# Altium schematic sheet MainBoard_ETH_RASP.SchDoc
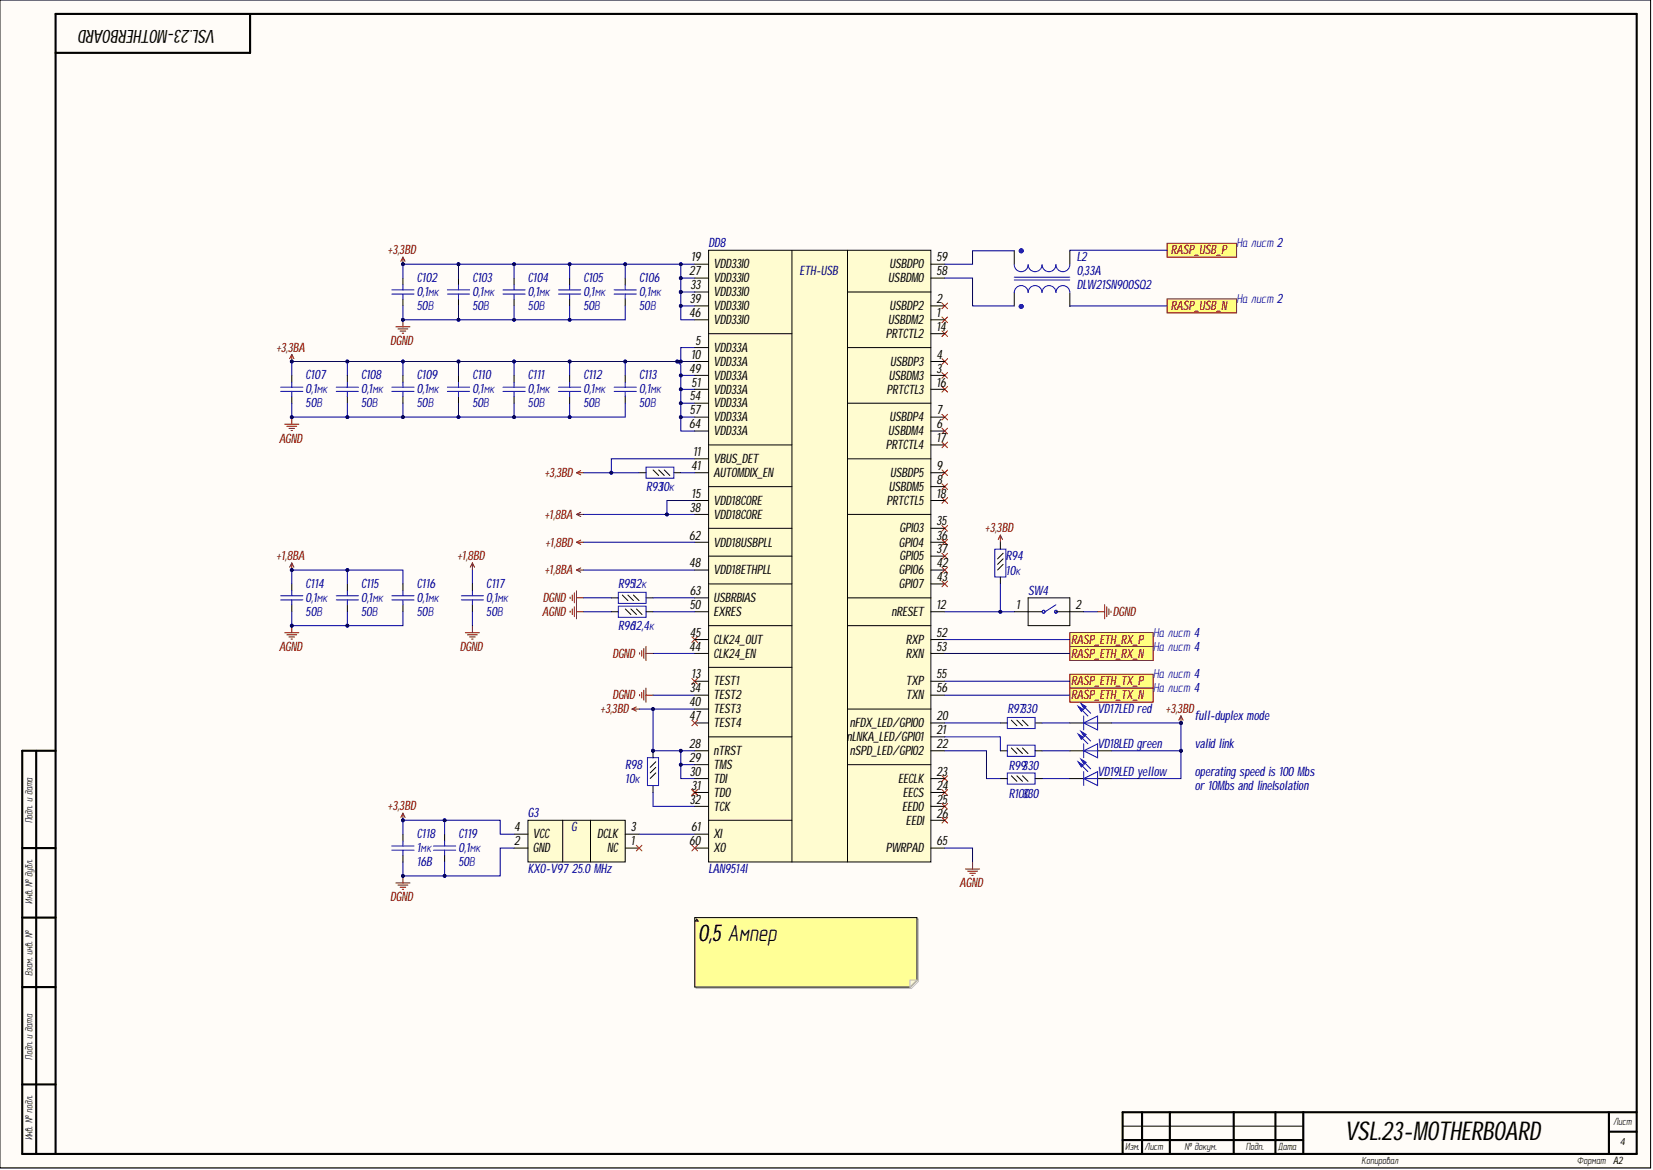
[diagram p0: MainBoard_ETH_RASP.SchDoc]

## Parts
C102  value 0,1мк  Voltage: 50В  [C0402]  (hidden comment: Capacitor)
C103  value 0,1мк  Voltage: 50В  [C0402]  (hidden comment: Capacitor)
C104  value 0,1мк  Voltage: 50В  [C0402]  (hidden comment: Capacitor)
C105  value 0,1мк  Voltage: 50В  [C0402]  (hidden comment: Capacitor)
C106  value 0,1мк  Voltage: 50В  [C0402]  (hidden comment: Capacitor)
C107  value 0,1мк  Voltage: 50В  [C0402]  (hidden comment: Capacitor)
C108  value 0,1мк  Voltage: 50В  [C0402]  (hidden comment: Capacitor)
C109  value 0,1мк  Voltage: 50В  [C0402]  (hidden comment: Capacitor)
C110  value 0,1мк  Voltage: 50В  [C0402]  (hidden comment: Capacitor)
C111  value 0,1мк  Voltage: 50В  [C0402]  (hidden comment: Capacitor)
C112  value 0,1мк  Voltage: 50В  [C0402]  (hidden comment: Capacitor)
C113  value 0,1мк  Voltage: 50В  [C0402]  (hidden comment: Capacitor)
C114  value 0,1мк  Voltage: 50В  [C0402]  (hidden comment: Capacitor)
C115  value 0,1мк  Voltage: 50В  [C0402]  (hidden comment: Capacitor)
C116  value 0,1мк  Voltage: 50В  [C0402]  (hidden comment: Capacitor)
C117  value 0,1мк  Voltage: 50В  [C0402]  (hidden comment: Capacitor)
C118  value 1мк  Voltage: 16B  [C0603]  (hidden comment: Capacitor)
C119  value 0,1мк  Voltage: 50В  [C0402]  (hidden comment: Capacitor)
DD8  Part Number: LAN9514I  [QFN64]
G3  KXO  Part Number: KXO-V97 25.0 MHz  [QSMD7x5.2x1.8]
L2  Part Number: DLW21SN900SQ2  Rated Current: 0,33A  [CHOKE0805]  (hidden comment: Dual-Winding Inductor)
R93  value 10к  [R0402]  (hidden comment: Resistor_0,062Вт)
R94  value 10к  [R0402]  (hidden comment: Resistor_0,062Вт)
R95  value 12к  [R0402]  (hidden comment: Resistor_0,062Вт)
R96  value 12,4к  [R0402]  (hidden comment: Resistor_0,062Вт)
R97  value 330  [R0402]  (hidden comment: Resistor_0,062Вт)
R98  value 10к  [R0402]  (hidden comment: Resistor_0,062Вт)
R99  value 330  [R0402]  (hidden comment: Resistor_0,062Вт)
R100  value 330  [R0402]  (hidden comment: Resistor_0,062Вт)
SW4  DS1040-01  [JUMPER_2.54]  (value not drawn)
VD17  LED  Part Name: LED red  [L_0402_RED]
VD18  LED  Part Name: LED green  [L_0402_GREEN]
VD19  LED  Part Name: LED yellow  [L_0402_YELLOW]

## Ports
RASP_ETH_RX_N, RASP_ETH_RX_P, RASP_ETH_TX_N, RASP_ETH_TX_P, RASP_USB_N, RASP_USB_P

## Nets
+1,8BA: C114.2 C115.2 C116.2 DD8.15(VDD18CORE) DD8.38(VDD18CORE) DD8.48(VDD18ETHPLL)
+1,8BD: C117.2 DD8.62(VDD18USBPLL)
+3,3BA: C107.2 C108.2 C109.2 C110.2 C111.2 C112.2 C113.2 DD8.5(VDD33A) DD8.10(VDD33A) DD8.49(VDD33A) DD8.51(VDD33A) DD8.54(VDD33A) DD8.57(VDD33A) DD8.64(VDD33A)
+3,3BD: C102.2 C103.2 C104.2 C105.2 C106.2 C118.2 C119.2 DD8.11(VBUS_DET) DD8.19(VDD33IO) DD8.27(VDD33IO) DD8.28(nTRST) DD8.29(TMS) DD8.30(TDI) DD8.33(VDD33IO) DD8.39(VDD33IO) DD8.40(TEST3) DD8.46(VDD33IO) G3.4(VCC) R93.2 R94.1 R98.1 VD17.2 VD18.2 VD19.2
AGND: C107.1 C108.1 C109.1 C110.1 C111.1 C112.1 C113.1 C114.1 C115.1 C116.1 DD8.65(PWRPAD) R96.2
DGND: C102.1 C103.1 C104.1 C105.1 C106.1 C117.1 C118.1 C119.1 DD8.34(TEST2) DD8.44(CLK24_EN) G3.2(GND) R95.1 SW4.2
RASP_ETH_RX_N: DD8.53(RXN) ; port RASP_ETH_RX_N
RASP_ETH_RX_P: DD8.52(RXP) ; port RASP_ETH_RX_P
RASP_ETH_TX_N: DD8.56(TXN) ; port RASP_ETH_TX_N
RASP_ETH_TX_P: DD8.55(TXP) ; port RASP_ETH_TX_P
RASP_USB_N: L2.4 ; port RASP_USB_N
RASP_USB_P: L2.3 ; port RASP_USB_P
NetDD8_12: DD8.12(nRESET) R94.2 SW4.1
NetDD8_20: DD8.20(nFDX_LED/GPIO0) R97.1
NetDD8_21: DD8.21(nLNKA_LED/GPIO1) R99.1
NetDD8_22: DD8.22(nSPD_LED/GPIO2) R100.1
NetDD8_32: DD8.32(TCK) R98.2
NetDD8_41: DD8.41(AUTOMDIX_EN) R93.1
NetDD8_50: DD8.50(EXRES) R96.1
NetDD8_58: DD8.58(USBDM0) L2.2
NetDD8_59: DD8.59(USBDP0) L2.1
NetDD8_61: DD8.61(XI) G3.3(DCLK)
NetDD8_63: DD8.63(USBRBIAS) R95.2
NetR97_2: R97.2 VD17.1
NetR99_2: R99.2 VD18.1
NetR100_2: R100.2 VD19.1

Not connected: DD8 1(USBDM2) 2(USBDP2) 3(USBDM3) 4(USBDP3) 6(USBDM4) 7(USBDP4) 8(USBDM5) 9(USBDP5) 13(TEST1) 14(PRTCTL2) 16(PRTCTL3) 17(PRTCTL4) 18(PRTCTL5) 23(EECLK) 24(EECS) 25(EEDO) 26(EEDI) 31(TDO) 35(GPIO3) 36(GPIO4) 37(GPIO5) 42(GPIO6) 43(GPIO7) 45(CLK24_OUT) 47(TEST4) 60(XO); G3 1(NC)

## Library data of the parts
C102-C117 C119 = cap277: Конденсатор; Manufacture: Murata; Part Name: Ceramic; Part Number: GRM155R71H104KE14D; Database Table Name: Capacitors$; tga: 0,10%; TKE: X7R; Component Kind: Standard; id: cap277; Library Name: MOP44.DbLib
C118 = cap133: Конденсатор; Manufacture: Murata; Part Name: Ceramic; Part Number: GRM188R71C105KA12D**; Database Table Name: Capacitors$; TKE: X7R; Component Kind: Standard; id: cap133; Library Name: MOP44.DbLib
DD8 = interf25: USB 2.0 Hub and 10/100 Ethernet Controller; Manufacture: Microchip; Current Consumption: 288мА; Database Table Name: Interface$; Number of Channel: 4; Power Supply: 3,3В; Type Supply: Single Polar; Component Kind: Standard; id: interf25; Library Name: MOP44.DbLib; Part Name: USB 2.0 Hub and 10/100 Ethernet Controller
G3 = crystal9: Кварц. генер. 32.768 МГц (HCMOS/TTL); Manufacture: GEYER; Database Table Name: Crystal$; Frequence: 25MHz; Power Supply: 3,3B; Tempreture MAX: +70°С; Tempreture MIN: -20°С; Component Kind: Standard; id: crystal9; Library Name: MOP44.DbLib
L2 = ind68: Синфазный дроссель; Manufacture: Murata; Part Name: Ind Common Mode Choke USB EMI; Database Table Name: Inductor$; Resistance: 0,35Ohm; Component Kind: Standard; id: ind68; Library Name: MOP44.DbLib
R93 R94 R98 = res294: Резистор; Manufacture: Yageo; Part Number: RC0402FR-0710KL; Accuracy: 0,01; Database Table Name: Resistor$; Component Kind: Standard; id: res294; Library Name: MOP44.DbLib; Part Name: Resistor; Power: 0,062Вт
R95 = res296: Резистор; Manufacture: Yageo; Part Number: RC0402FR-0712KL; Accuracy: 0,01; Database Table Name: Resistor$; Component Kind: Standard; id: res296; Library Name: MOP44.DbLib; Part Name: Resistor; Power: 0,062Вт
R96 = res979: Резистор; Manufacture: Yageo; Part Number: RC0402FR-0712K4L; Accuracy: 0,01; Database Table Name: Resistor$; Component Kind: Standard; id: res979; Library Name: MOP44.DbLib; Part Name: Resistor; Power: 0,062Вт
R97 R100 = res258: Резистор; Manufacture: Yageo; Part Number: RC0402FR-07330RL; Accuracy: 0,01; Database Table Name: Resistor$; Component Kind: Standard; id: res258; Library Name: MOP44.DbLib; Part Name: Resistor; Power: 0,062Вт
R99 = res258: Резистор; Manufacture: Yageo; Part Number: RC0402FR-07330RL; Accuracy: 0,01; Database Table Name: Resistor$; Value: 330; Component Kind: Standard; id: res258; Library Name: MOP44.DbLib; Part Name: Resistor; Power: 0,062Вт
SW4 = btn9: DIP переключатель; Part Name: Перемычка адреса; Database Table Name: Button$; Etch: 2,54; Component Kind: Standard; id: btn9; Library Name: MOP44.DbLib
VD17 = diode28: Светодиод; Part Number: KPHHS-1005SURCK; Database Table Name: Diode$; Component Kind: Standard; id: diode28; Library Name: MOP44.DbLib
VD18 = diode30: Светодиод; Part Number: KPHHS-1005MGCK; Database Table Name: Diode$; Component Kind: Standard; id: diode30; Library Name: MOP44.DbLib
VD19 = diode29: Светодиод; Part Number: KPHHS-1005SYCK; Database Table Name: Diode$; Component Kind: Standard; id: diode29; Library Name: MOP44.DbLib
